# Altium schematic sheet ADC_Configuration.SchDoc
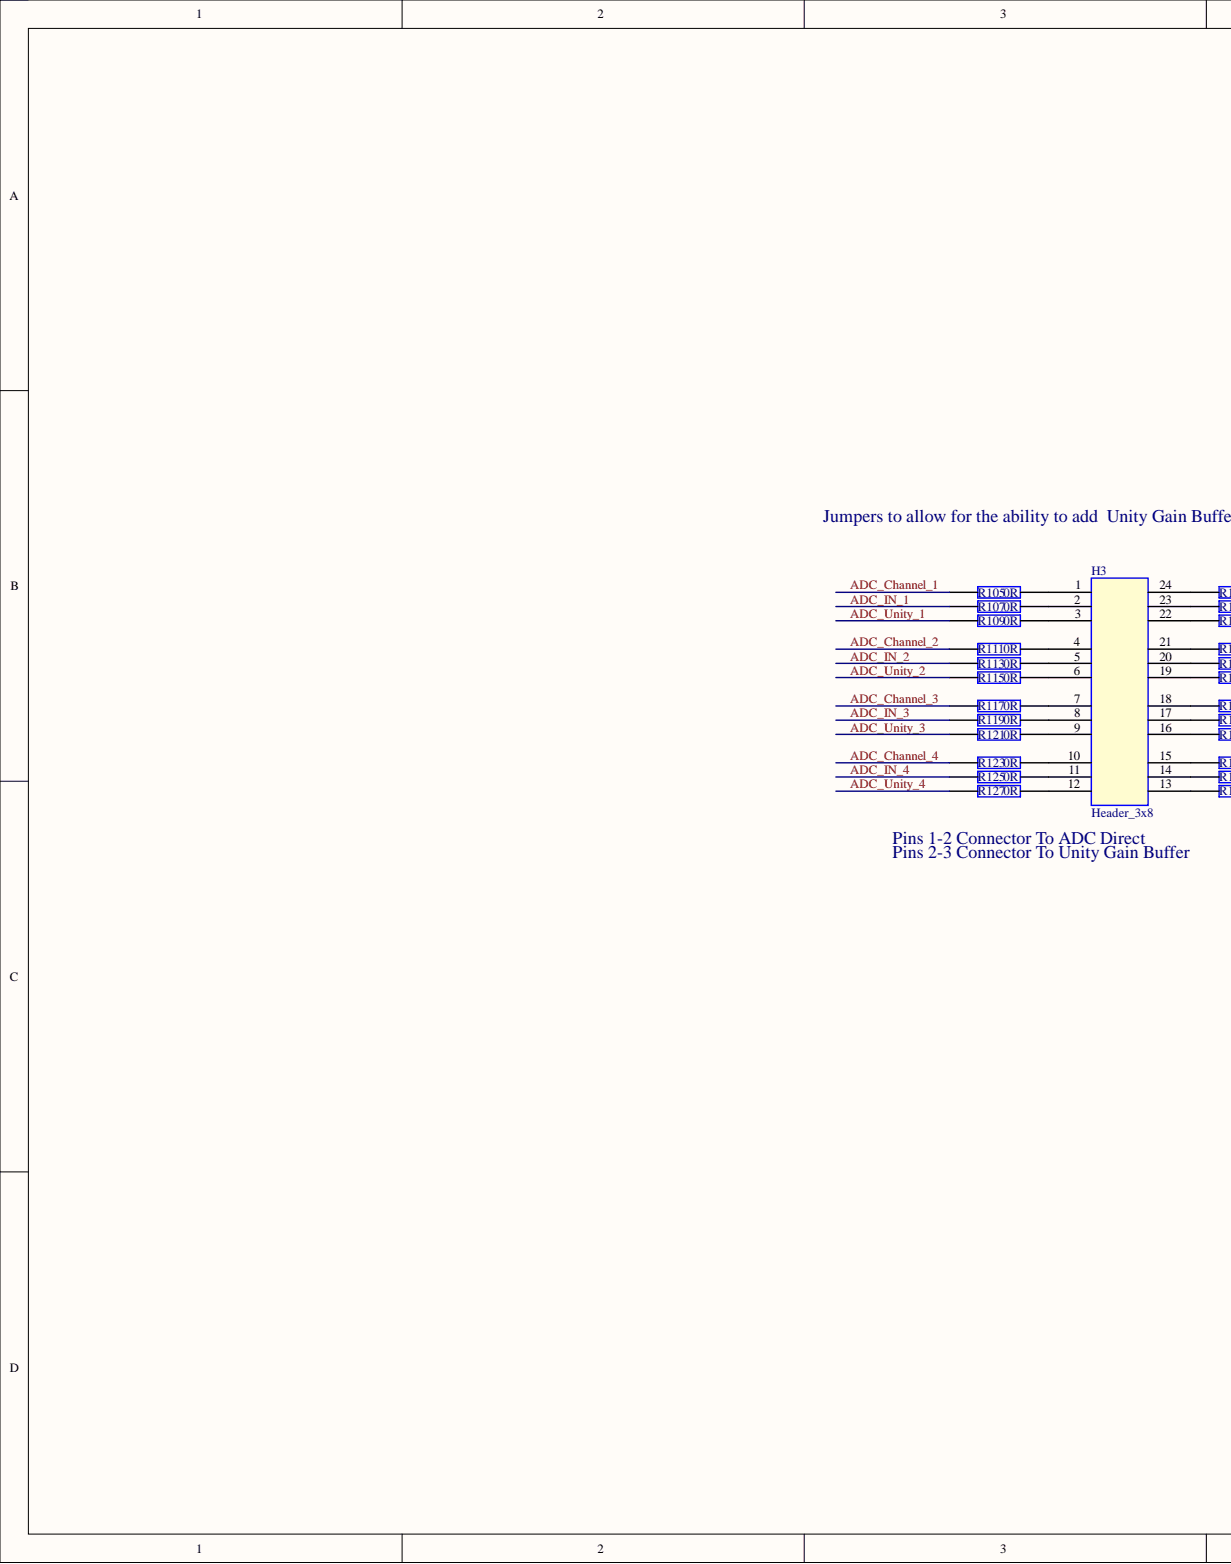
[diagram p0: ADC_Configuration.SchDoc, left]
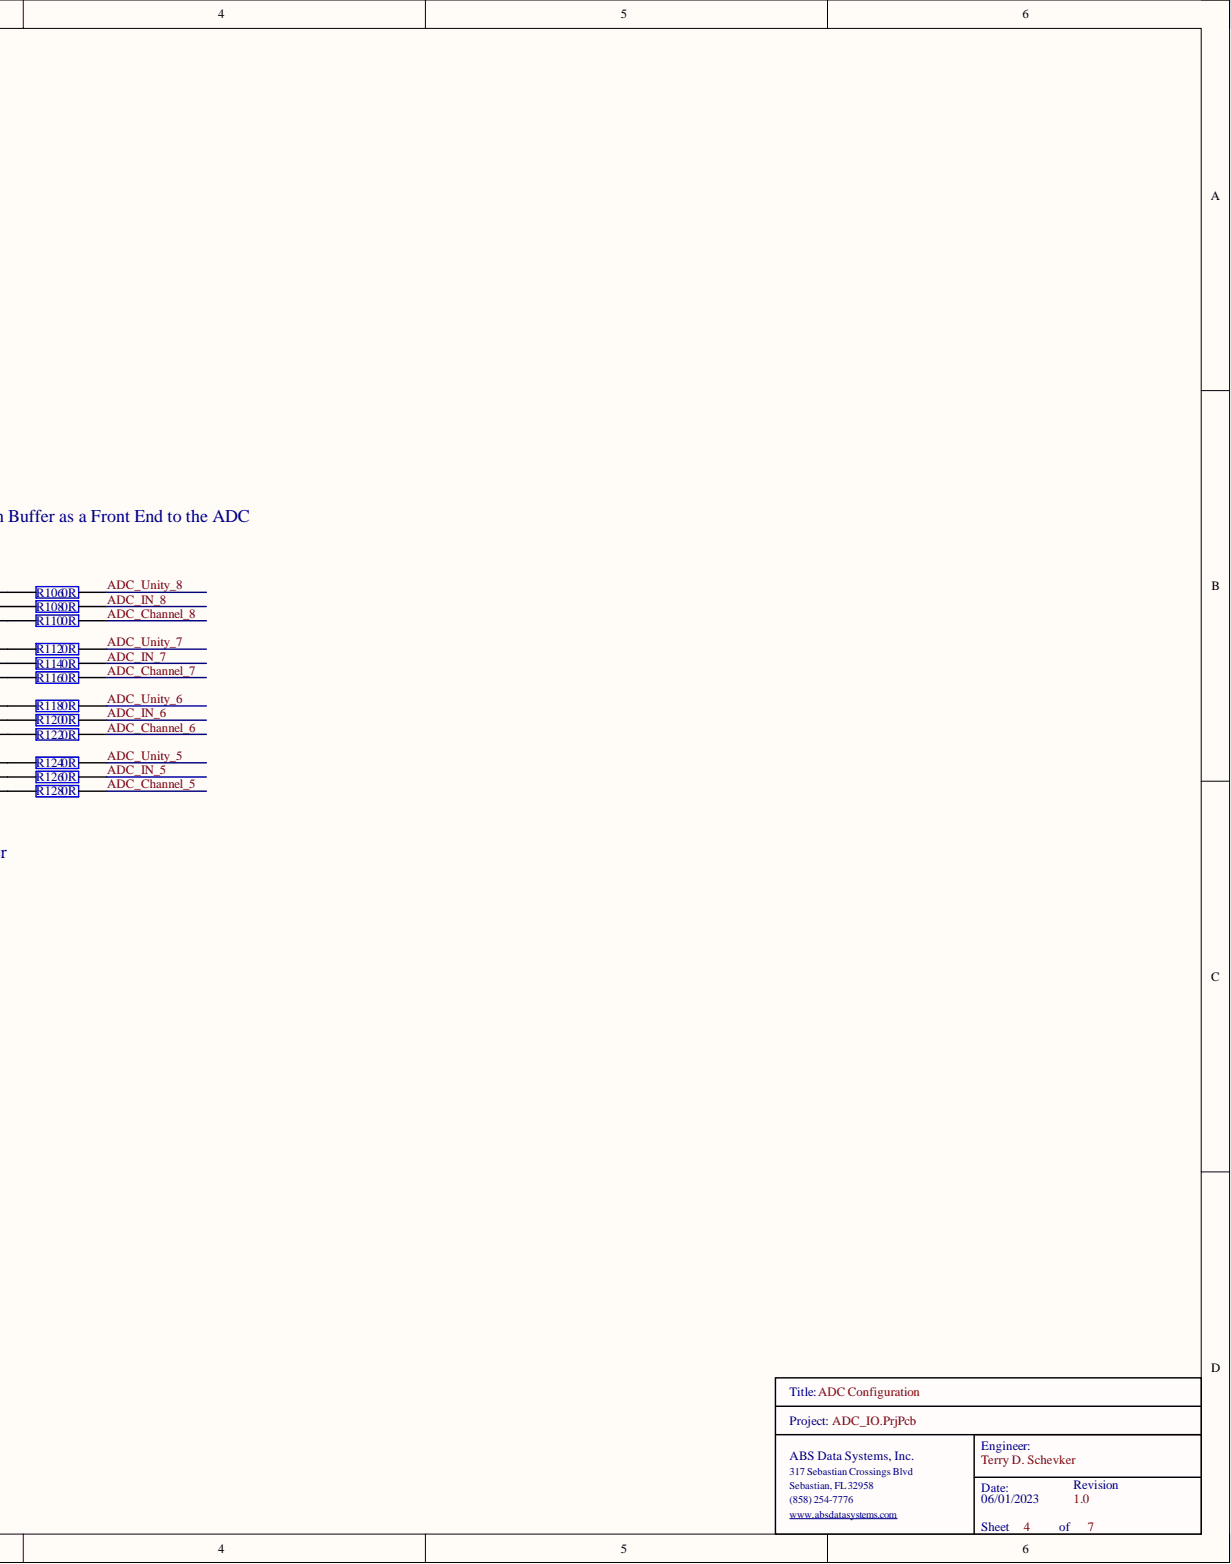
[diagram p1: ADC_Configuration.SchDoc, right]

## Parts
H3  Header_3x8  [HDR3X8]
R105  0R  [0603]  (value not drawn)
R106  0R  [0603]  (value not drawn)
R107  0R  [0603]  (value not drawn)
R108  0R  [0603]  (value not drawn)
R109  0R  [0603]  (value not drawn)
R110  0R  [0603]  (value not drawn)
R111  0R  [0603]  (value not drawn)
R112  0R  [0603]  (value not drawn)
R113  0R  [0603]  (value not drawn)
R114  0R  [0603]  (value not drawn)
R115  0R  [0603]  (value not drawn)
R116  0R  [0603]  (value not drawn)
R117  0R  [0603]  (value not drawn)
R118  0R  [0603]  (value not drawn)
R119  0R  [0603]  (value not drawn)
R120  0R  [0603]  (value not drawn)
R121  0R  [0603]  (value not drawn)
R122  0R  [0603]  (value not drawn)
R123  0R  [0603]  (value not drawn)
R124  0R  [0603]  (value not drawn)
R125  0R  [0603]  (value not drawn)
R126  0R  [0603]  (value not drawn)
R127  0R  [0603]  (value not drawn)
R128  0R  [0603]  (value not drawn)

## Nets
ADC_Channel_1: R105.1
ADC_Channel_2: R111.1
ADC_Channel_3: R117.1
ADC_Channel_4: R123.1
ADC_Channel_5: R128.2
ADC_Channel_6: R122.2
ADC_Channel_7: R116.2
ADC_Channel_8: R110.2
ADC_IN_1: R107.1
ADC_IN_2: R113.1
ADC_IN_3: R119.1
ADC_IN_4: R125.1
ADC_IN_5: R126.2
ADC_IN_6: R120.2
ADC_IN_7: R114.2
ADC_IN_8: R108.2
ADC_Unity_1: R109.1
ADC_Unity_2: R115.1
ADC_Unity_3: R121.1
ADC_Unity_4: R127.1
ADC_Unity_5: R124.2
ADC_Unity_6: R118.2
ADC_Unity_7: R112.2
ADC_Unity_8: R106.2
NetH3_1: H3.1 R105.2
NetH3_2: H3.2 R107.2
NetH3_3: H3.3 R109.2
NetH3_4: H3.4 R111.2
NetH3_5: H3.5 R113.2
NetH3_6: H3.6 R115.2
NetH3_7: H3.7 R117.2
NetH3_8: H3.8 R119.2
NetH3_9: H3.9 R121.2
NetH3_10: H3.10 R123.2
NetH3_11: H3.11 R125.2
NetH3_12: H3.12 R127.2
NetH3_13: H3.13 R128.1
NetH3_14: H3.14 R126.1
NetH3_15: H3.15 R124.1
NetH3_16: H3.16 R122.1
NetH3_17: H3.17 R120.1
NetH3_18: H3.18 R118.1
NetH3_19: H3.19 R116.1
NetH3_20: H3.20 R114.1
NetH3_21: H3.21 R112.1
NetH3_22: H3.22 R110.1
NetH3_23: H3.23 R108.1
NetH3_24: H3.24 R106.1

## Library data of the parts
H3 = Header_3x8: 16 Pin 3x8 Through Hold Jumprts; Manufacturer: Samtec; Manufacturer Part Number: TST-108-01-G-D; Connector Type: Male Pin; Gender: Male; Number of Contacts: 16; Pitch: 2.54mm; Row Spacing: 0.1inch; Category: Headers and Wire Housings; Color: Black; Component Kind: Standard; ComponentLink1Description: https://datasheet.ciiva.com/739/tst-mkt-739845.pdf?src-supplier=Digi-Key; ComponentLink1URL: https://datasheet.ciiva.com/739/tst-mkt-739845.pdf?src-supplier=Digi-Key; ComponentLink2Description: https://datasheet.ciiva.com/10018/htst-10018510.pdf?src-supplier=Avnet; ComponentLink2URL: https://datasheet.ciiva.com/10018/htst-10018510.pdf?src-supplier=Avnet; Contact Material: Bronze; Contact Mating Length: 0.25inch; Contact Plating: Gold; Depth: 9.27mm; Housing Color: Black; Housing Material: Polyester; Length: 27.94mm; Library Name: Misc.SchLib; Max Operating Temperature: 125°C; Min Operating Temperature: -55°C; Mount: Through Hole; Number of Positions: 16; Number of Rows: 2; Orientation: Straight; Packaging: Rail/Tube; Pricing 1: 1=2.85000, 10=2.73600, 100=2.28000, 500=1.93800, 1000=1.65300, 5000=1.48200 (USD); REACH SVHC: No SVHC; RoHS: Yes; Stock 1: 227; Supplier 1: Digi-Key; Termination: Solder
R105-R128 = RC0603JR-070RL: YAGEO 0603 0 ohm; Manufacturer_Name: KEMET; Geometry.Height: 0.55mm; Component Kind: Standard; Datasheet Link: https://datasheet.datasheetarchive.com/originals/distributors/Datasheets_SAMA/902f9e387b938f871d31120f5fc1d65e.pdf; Library Name: Resistors.SchLib; Manufacturer_Part_Number: RC0603JR-070RL; Mouser Part Number: 603-RC0603JR-070RL; Mouser Price/Stock: https://www.mouser.co.uk/ProductDetail/YAGEO/RC0603JR-070RL?qs=2cAdsCoAWRGWyPcuXgGiXQ%3D%3D
